# Altium schematic sheet ESP32_CAN_V2-can.SchDoc
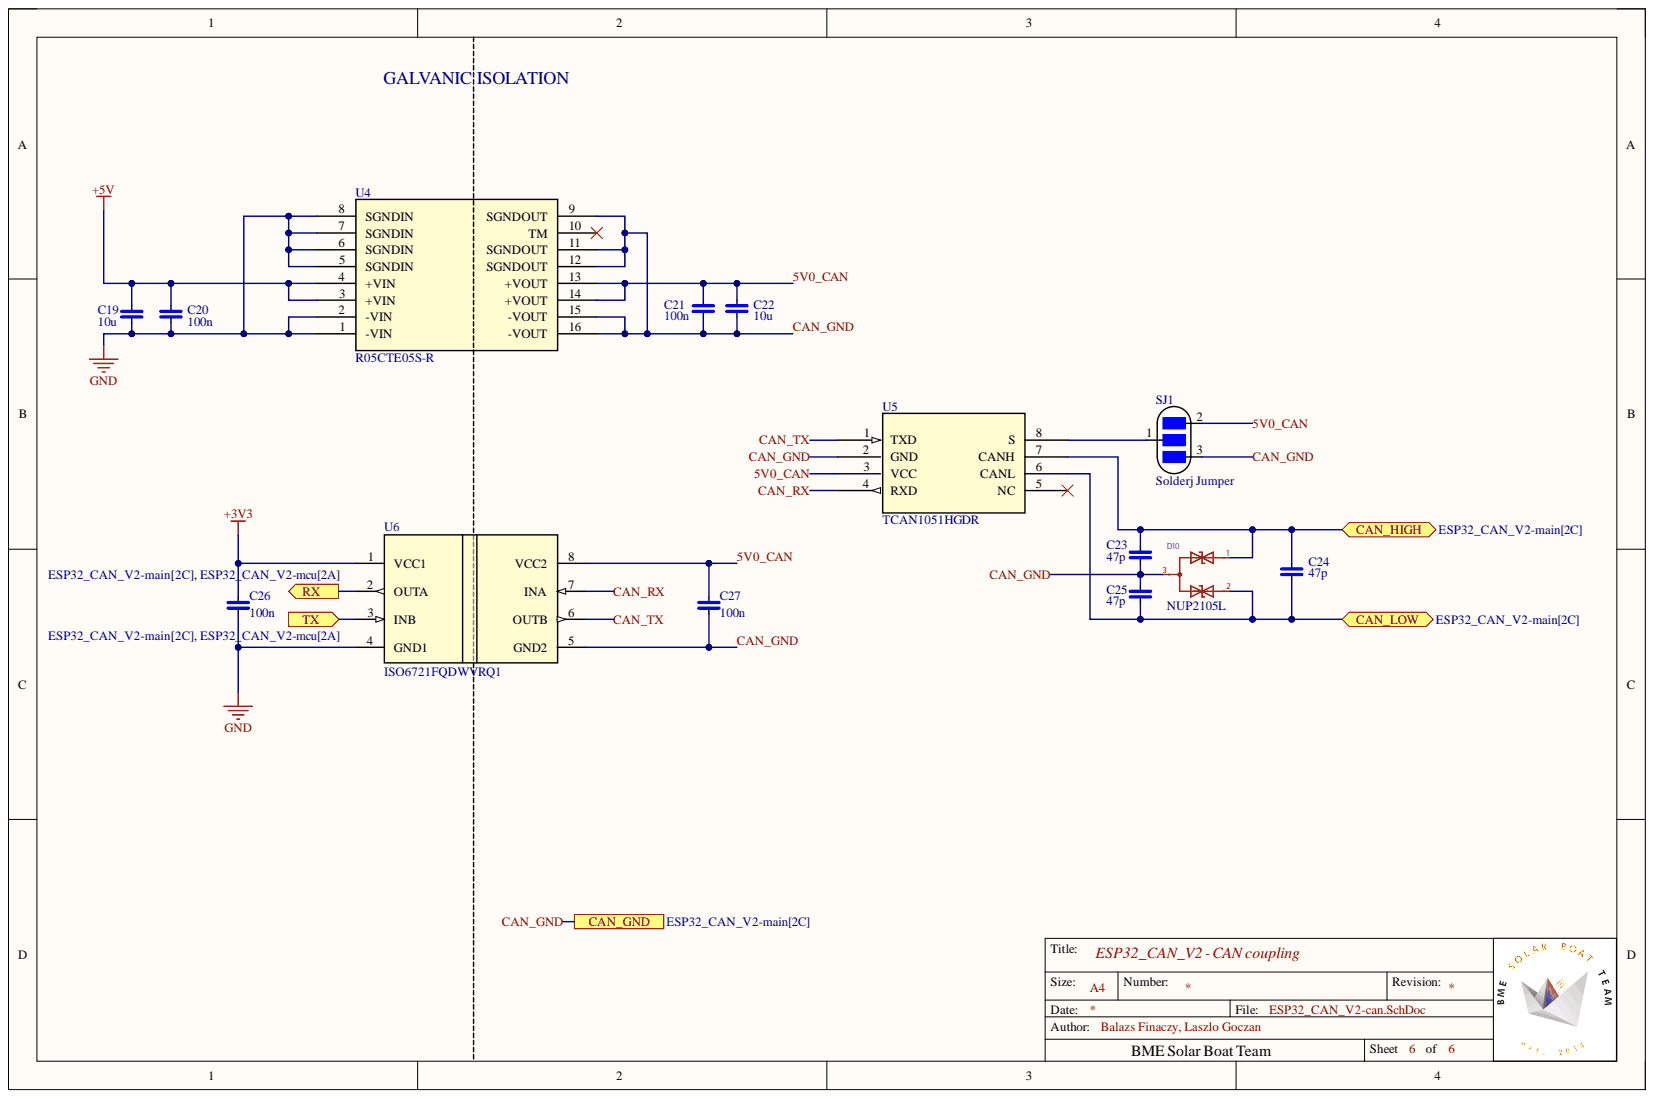
[diagram p0: ESP32_CAN_V2-can.SchDoc]

## Parts
C19  10u  [C0805]
C20  100n  [C0603]
C21  100n  [C0603]
C22  10u  [C0805]
C23  47p  [C0603]
C24  47p  [C0603]
C25  47p  [C0603]
C26  100n  [C0603]
C27  100n  [C0603]
D10  NUP2105L
SJ1  Solderj Jumper  [SolderJumper 1x2]
U4  R05CTE05S-R  [SMD_16_10.35X7.5X2.5_RCP]
U5  TCAN1051HGDR  [D8_TEX]
U6  ISO6721FQDWVRQ1  [DWV0008A-IPC_A]

## Ports
CAN_GND, CAN_HIGH, CAN_LOW, RX, TX

## Nets
+3V3: C26.2 U6.1(VCC1)
+5V: C19.2 C20.2 U4.3(+VIN) U4.4(+VIN)
GND: C19.1 C20.1 C26.1 U4.1(-VIN) U4.2(-VIN) U4.5(SGNDIN) U4.6(SGNDIN) U4.7(SGNDIN) U4.8(SGNDIN) U6.4(GND1)
5V0_CAN_6: C21.2 C22.2 C27.2 SJ1.2 U4.13(+VOUT) U4.14(+VOUT) U5.3(VCC) U6.8(VCC2)  [also labelled 5V0_CAN]
CAN_GND: C21.1 C22.1 C23.1 C25.2 C27.1 D10.3 SJ1.3 U4.9(SGNDOUT) U4.11(SGNDOUT) U4.12(SGNDOUT) U4.15(-VOUT) U4.16(-VOUT) U5.2(GND) U6.5(GND2) ; port CAN_GND
CAN_HIGH: C23.2 C24.2 D10.1 U5.7(CANH) ; port CAN_HIGH
CAN_LOW: C24.1 C25.1 D10.2 U5.6(CANL) ; port CAN_LOW
CAN_RX_6: U5.4(RXD) U6.7(INA)  [also labelled CAN_RX]
CAN_TX_6: U5.1(TXD) U6.6(OUTB)  [also labelled CAN_TX]
RX: U6.2(OUTA) ; port RX
TX: U6.3(INB) ; port TX
NetSJ1_1: SJ1.1 U5.8(S)
NetU4_10: U4.10(TM)
NetU5_5: U5.5(NC)

## Library data of the parts
C19-C27 = C: Component Kind: Standard; Library Name: ESP32_CAN_V2.SchLib
D10 = TVS-Bidirectional-Diode_Dual: ki_description: TVS Dual Diode; Component Kind: Standard; ki_keywords: TVS Dual Diode; Library Name: ESP32_CAN_V2.SchLib
SJ1 = Solder Jumper 1x2: Component Kind: Standard; Library Name: ESP32_CAN_V2.SchLib
U4 = R05CTE05S-R: DC/DC converter; Manufacturer_Name: Recom Power; Component Kind: Standard; Library Name: ESP32_CAN_V2.SchLib; Manufacturer_Part_Number: R05CTE05S-R; RefDes: RefDes; Type: Type; Copyright: Copyright (C) 2026 Ultra Librarian. All rights reserved.
U5 = TCAN1051HGDR: Fault Protected CAN Transceiver With Flexible Data-Rate 8-SOIC -55 to 125; Manufacturer_Name: Texas Instruments; Component Kind: Standard; Datasheet: https://www.ti.com/lit/gpn/tcan1051hg; Library Name: ESP32_CAN_V2.SchLib; Manufacturer_Part_Number: TCAN1051HGDR; RefDes: RefDes; Type: Type; Copyright: Copyright (C) 2026 Ultra Librarian. All rights reserved.
U6 = ISO6721FQDWVRQ1: Automotive, general-purpose, dual-channel, 1/1 digital isolator 8-SOIC -40 to 125; Manufacturer_Name: Texas Instruments; Component Kind: Standard; Datasheet: https://www.ti.com/lit/gpn/iso6721-q1; Library Name: ESP32_CAN_V2.SchLib; Manufacturer_Part_Number: ISO6721FQDWVRQ1; RefDes: RefDes; TYPE: TYPE; Copyright: Copyright (C) 2026 Ultra Librarian. All rights reserved.
